# Altium schematic sheet LED_Button.SchDoc
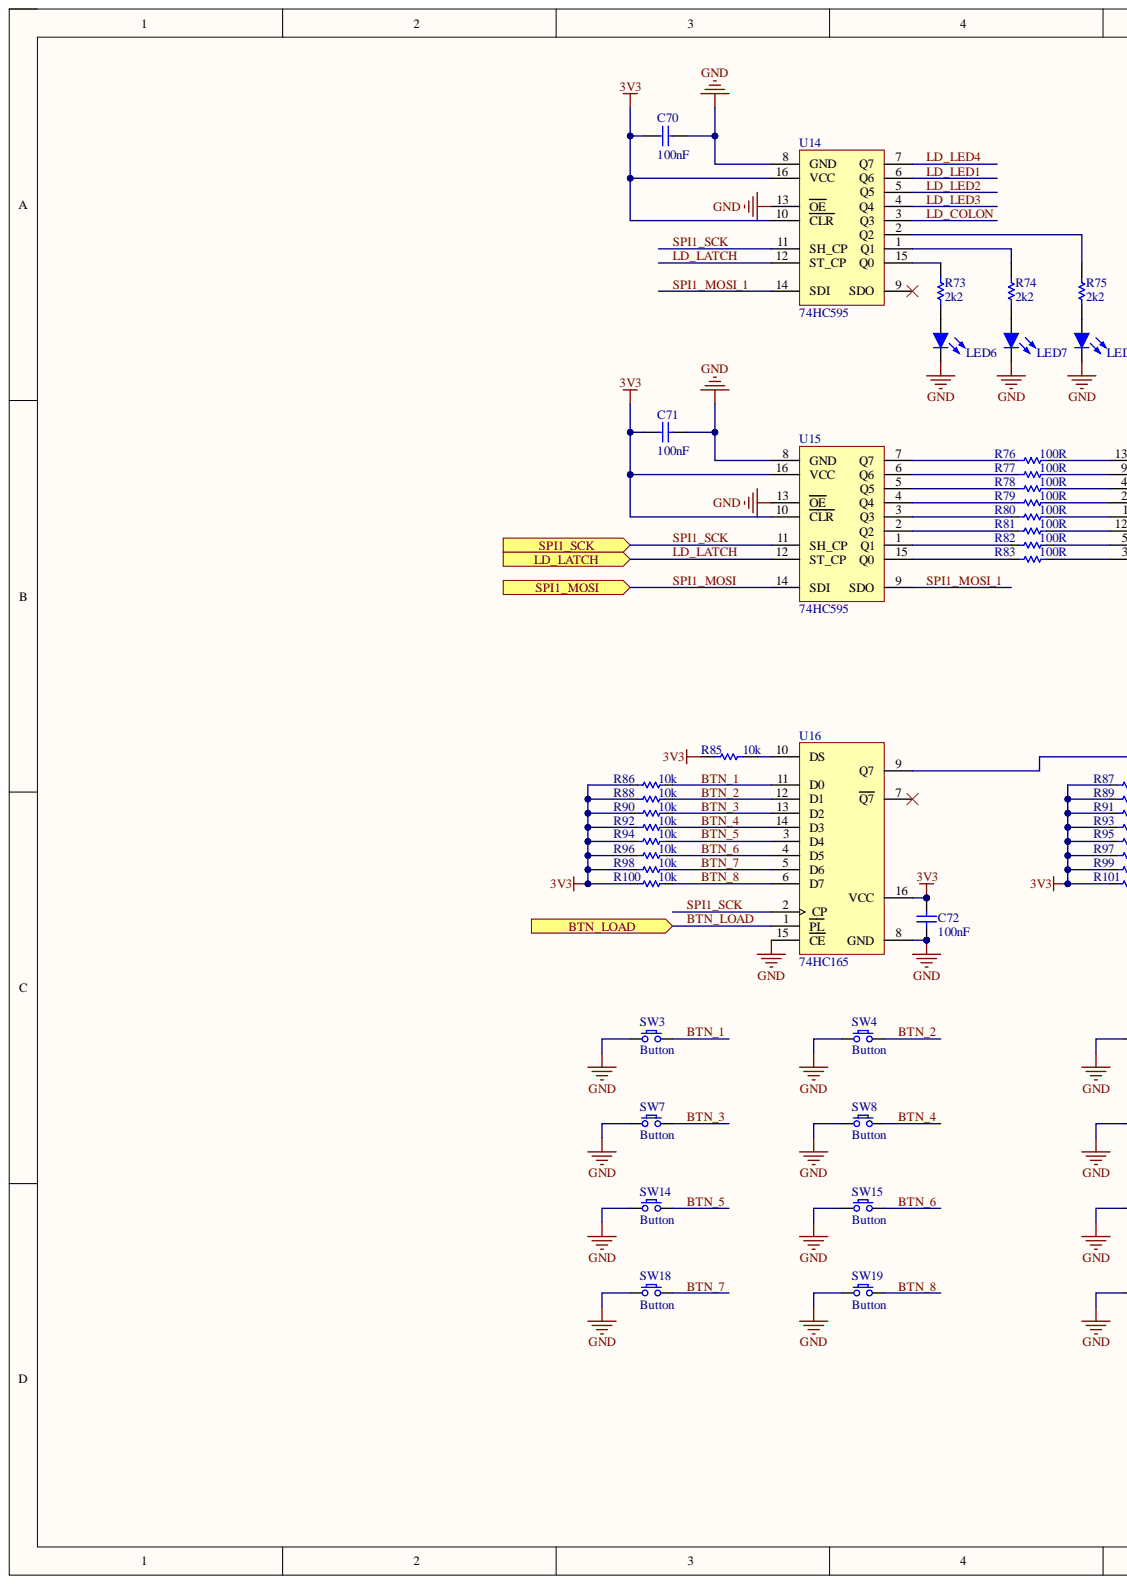
[diagram p0: LED_Button.SchDoc, left]
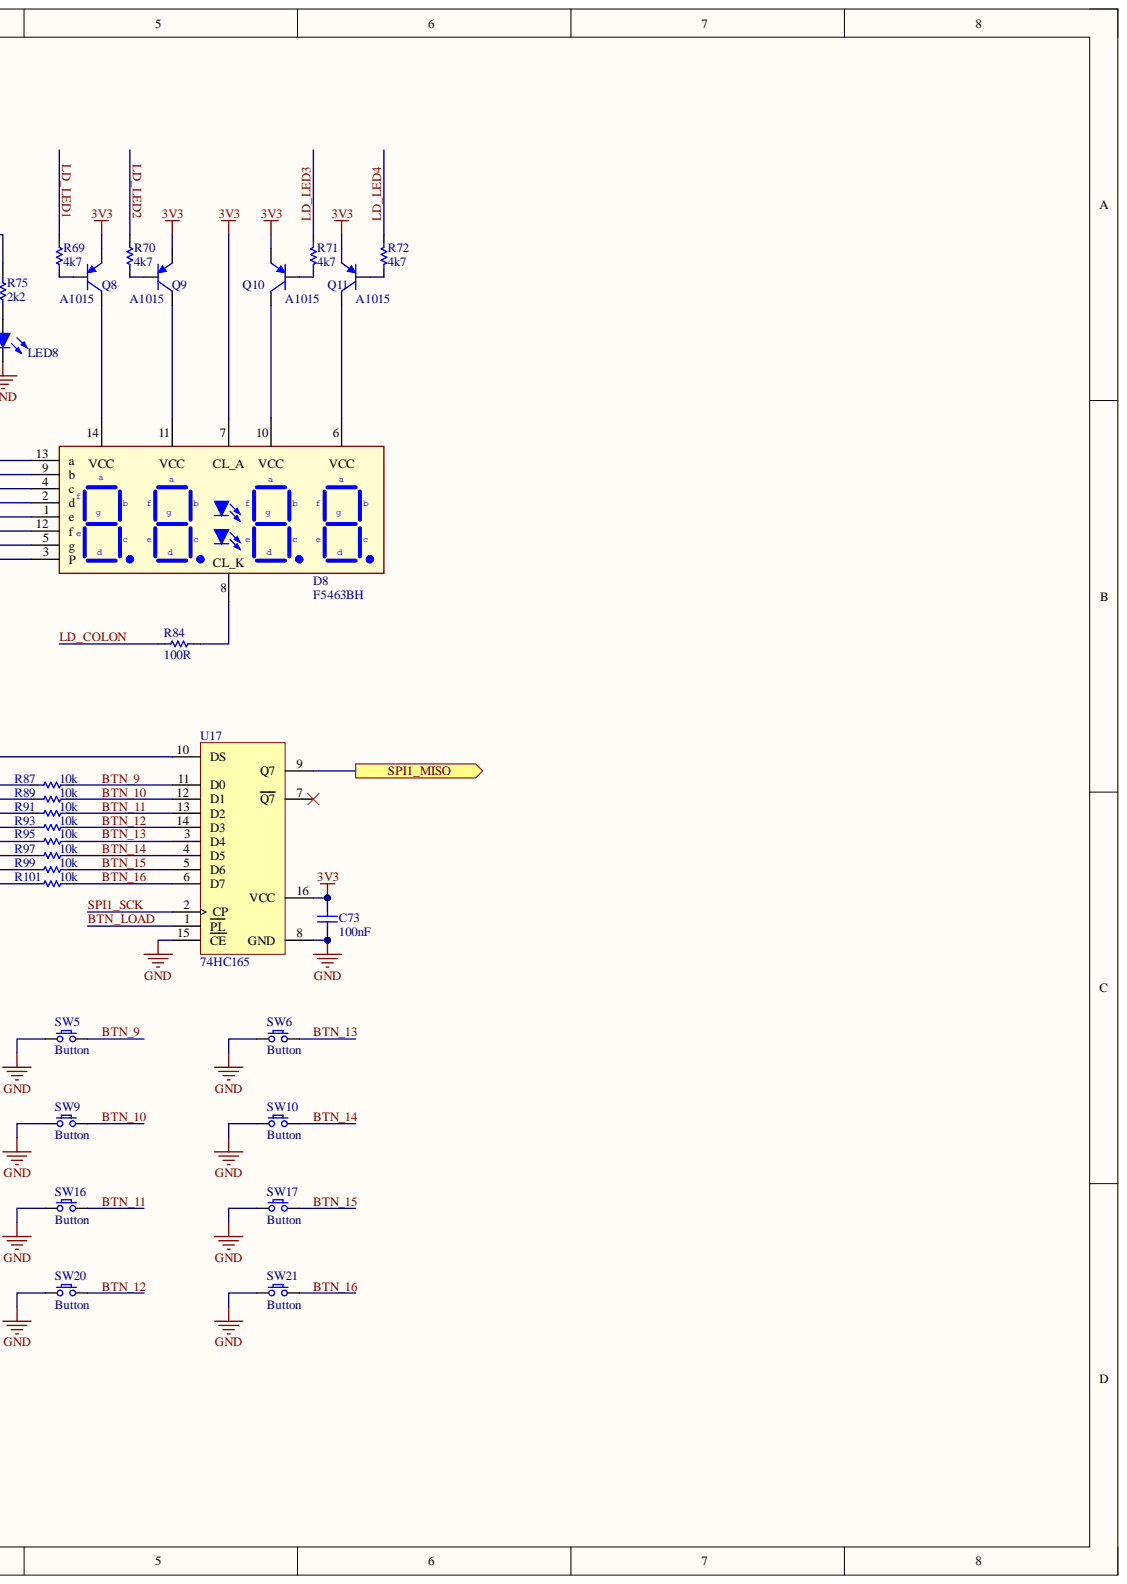
[diagram p1: LED_Button.SchDoc, right]

## Parts
C70  100nF  [C 0603 (1608)]
C71  100nF  [C 0603 (1608)]
C72  100nF  [C 0603 (1608)]
C73  100nF  [C 0603 (1608)]
D8  F5463BH  [LED7_4 CA - COLON]
LED6  Led-Blue  [Led SMD 0603 BLUE]  (value not drawn)
LED7  Led-Blue  [Led SMD 0603 BLUE]  (value not drawn)
LED8  Led-Blue  [Led SMD 0603 BLUE]  (value not drawn)
Q8  A1015  [SOT-23]
Q9  A1015  [SOT-23]
Q10  A1015  [SOT-23]
Q11  A1015  [SOT-23]
R69  4k7  [R 0603 (1608)]
R70  4k7  [R 0603 (1608)]
R71  4k7  [R 0603 (1608)]
R72  4k7  [R 0603 (1608)]
R73  2k2  [R 0603 (1608)]
R74  2k2  [R 0603 (1608)]
R75  2k2  [R 0603 (1608)]
R76  100R  [R 0603 (1608)]
R77  100R  [R 0603 (1608)]
R78  100R  [R 0603 (1608)]
R79  100R  [R 0603 (1608)]
R80  100R  [R 0603 (1608)]
R81  100R  [R 0603 (1608)]
R82  100R  [R 0603 (1608)]
R83  100R  [R 0603 (1608)]
R84  100R  [R 0603 (1608)]
R85  10k  [R 0603 (1608)]
R86  10k  [R 0603 (1608)]
R87  10k  [R 0603 (1608)]
R88  10k  [R 0603 (1608)]
R89  10k  [R 0603 (1608)]
R90  10k  [R 0603 (1608)]
R91  10k  [R 0603 (1608)]
R92  10k  [R 0603 (1608)]
R93  10k  [R 0603 (1608)]
R94  10k  [R 0603 (1608)]
R95  10k  [R 0603 (1608)]
R96  10k  [R 0603 (1608)]
R97  10k  [R 0603 (1608)]
R98  10k  [R 0603 (1608)]
R99  10k  [R 0603 (1608)]
R100  10k  [R 0603 (1608)]
R101  10k  [R 0603 (1608)]
SW3  Button  [SW/2/SMD - 3x4x2]
SW4  Button  [SW/2/SMD - 3x4x2]
SW5  Button  [SW/2/SMD - 3x4x2]
SW6  Button  [SW/2/SMD - 3x4x2]
SW7  Button  [SW/2/SMD - 3x4x2]
SW8  Button  [SW/2/SMD - 3x4x2]
SW9  Button  [SW/2/SMD - 3x4x2]
SW10  Button  [SW/2/SMD - 3x4x2]
SW14  Button  [SW/2/SMD - 3x4x2]
SW15  Button  [SW/2/SMD - 3x4x2]
SW16  Button  [SW/2/SMD - 3x4x2]
SW17  Button  [SW/2/SMD - 3x4x2]
SW18  Button  [SW/2/SMD - 3x4x2]
SW19  Button  [SW/2/SMD - 3x4x2]
SW20  Button  [SW/2/SMD - 3x4x2]
SW21  Button  [SW/2/SMD - 3x4x2]
U14  74HC595  [SOIC-16]
U15  74HC595  [SOIC-16]
U16  74HC165  [SOIC-16]
U17  74HC165  [SOIC-16]

## Ports
BTN_LOAD, LD_LATCH, SPI1_MISO, SPI1_MOSI, SPI1_SCK

## Nets
3V3: C70.1 C71.1 C72.1 C73.1 D8.7 Q8.2 Q9.2 Q10.2 Q11.2 R85.2 R86.1 R87.1 R88.1 R89.1 R90.1 R91.1 R92.1 R93.1 R94.1 R95.1 R96.1 R97.1 R98.1 R99.1 R100.1 R101.1 U14.10(CLR) U14.16(VCC) U15.10(CLR) U15.16(VCC) U16.16(VCC) U17.16(VCC)
GND: C70.2 C71.2 C72.2 C73.2 LED6.2 LED7.2 LED8.2 SW3.1 SW4.1 SW5.1 SW6.1 SW7.1 SW8.1 SW9.1 SW10.1 SW14.1 SW15.1 SW16.1 SW17.1 SW18.1 SW19.1 SW20.1 SW21.1 U14.8(GND) U14.13(OE) U15.8(GND) U15.13(OE) U16.8(GND) U16.15(CE) U17.8(GND) U17.15(CE)
BTN_1: R86.2 SW3.2 U16.11(D0)
BTN_2: R88.2 SW4.2 U16.12(D1)
BTN_3: R90.2 SW7.2 U16.13(D2)
BTN_4: R92.2 SW8.2 U16.14(D3)
BTN_5: R94.2 SW14.2 U16.3(D4)
BTN_6: R96.2 SW15.2 U16.4(D5)
BTN_7: R98.2 SW18.2 U16.5(D6)
BTN_8: R100.2 SW19.2 U16.6(D7)
BTN_9: R87.2 SW5.2 U17.11(D0)
BTN_10: R89.2 SW9.2 U17.12(D1)
BTN_11: R91.2 SW16.2 U17.13(D2)
BTN_12: R93.2 SW20.2 U17.14(D3)
BTN_13: R95.2 SW6.2 U17.3(D4)
BTN_14: R97.2 SW10.2 U17.4(D5)
BTN_15: R99.2 SW17.2 U17.5(D6)
BTN_16: R101.2 SW21.2 U17.6(D7)
BTN_LOAD: U16.1(PL) U17.1(PL) ; port BTN_LOAD
LD_COLON: R84.2 U14.3(Q3)
LD_LATCH: U14.12(ST_CP) U15.12(ST_CP) ; port LD_LATCH
LD_LED1: R69.2 U14.6(Q6)
LD_LED2: R70.2 U14.5(Q5)
LD_LED3: R71.1 U14.4(Q4)
LD_LED4: R72.1 U14.7(Q7)
SPI1_MISO: U17.9(Q7) ; port SPI1_MISO
SPI1_MOSI: U15.14(SDI) ; port SPI1_MOSI
SPI1_MOSI_1: U14.14(SDI) U15.9(SDO)
SPI1_SCK: U14.11(SH_CP) U15.11(SH_CP) U16.2(CP) U17.2(CP) ; port SPI1_SCK
NetD8_1: D8.1(e) R80.2
NetD8_2: D8.2(d) R79.2
NetD8_3: D8.3(P) R83.2
NetD8_4: D8.4(c) R78.2
NetD8_5: D8.5(g) R82.2
NetD8_6: D8.6 Q11.3
NetD8_8: D8.8 R84.1
NetD8_9: D8.9(b) R77.2
NetD8_10: D8.10 Q10.3
NetD8_11: D8.11 Q9.3
NetD8_12: D8.12(f) R81.2
NetD8_13: D8.13(a) R76.2
NetD8_14: D8.14 Q8.3
NetLED6_1: LED6.1 R73.1
NetLED7_1: LED7.1 R74.1
NetLED8_1: LED8.1 R75.1
NetQ8_1: Q8.1 R69.1
NetQ9_1: Q9.1 R70.1
NetQ10_1: Q10.1 R71.2
NetQ11_1: Q11.1 R72.2
NetR73_2: R73.2 U14.15(Q0)
NetR74_2: R74.2 U14.1(Q1)
NetR75_2: R75.2 U14.2(Q2)
NetR76_1: R76.1 U15.7(Q7)
NetR77_1: R77.1 U15.6(Q6)
NetR78_1: R78.1 U15.5(Q5)
NetR79_1: R79.1 U15.4(Q4)
NetR80_1: R80.1 U15.3(Q3)
NetR81_1: R81.1 U15.2(Q2)
NetR82_1: R82.1 U15.1(Q1)
NetR83_1: R83.1 U15.15(Q0)
NetR85_1: R85.1 U16.10(DS)
NetU16_9: U16.9(Q7) U17.10(DS)

Not connected: U14 9(SDO); U16 7(Q7); U17 7(Q7)

## Library data of the parts
C70-C73 = Capacitor NP: Capacitor non-pol; Component Kind: Standard; Library Name: BKIT4U_LIB.SchLib
D8 = LED7-4SEG-COLON: Component Kind: Standard; Library Name: BKIT4U_LIB.SchLib
LED6-LED8 = Led: Typical LED; Component Kind: Standard; Library Name: BKIT4U_LIB.SchLib
Q8-Q11 = Transistor PNP: PNP Bipolar Transistor; Component Kind: Standard; LatestRevisionDate: 29-May-2009; LatestRevisionNote: IPC-7351 Footprint Added.; Library Name: BKIT4U_LIB.SchLib; PackageDescription: 3-Pin SOT-23 Package 0.95 mm Pitch, 2.4 mm Lead Span; PackageReference: SOT-23B; PackageVersion: Aug-1999; Published: 8-Jun-2000; Publisher: Altium Limited
R69-R101 = Resistor: Component Kind: Standard; Description: Resistor; LatestRevisionDate: 17-Jul-2002; LatestRevisionNote: Re-released for DXP Platform.; Library Name: BKIT4U_LIB.SchLib; PackageReference: AXIAL-0.3; Published: 8-Jun-2000; Publisher: Altium Limited
SW3-SW10 SW14-SW21 = SW SPST: Tact switch 2 pin; Component Kind: Standard; Library Name: BKIT4U_LIB.SchLib
U14 U15 = 74HC595: 8-bit serial-in, serial or parallel-out  shift register with output latches;  3-state; Component Kind: Standard; Library Name: BKIT4U_LIB.SchLib
U16 U17 = 74HC165: 8-bit serial-in, serial or parallel-out  shift register with output latches;  3-state; Component Kind: Standard; Library Name: BKIT4U_LIB.SchLib
